# Altium schematic sheet BaseStation.SchDoc
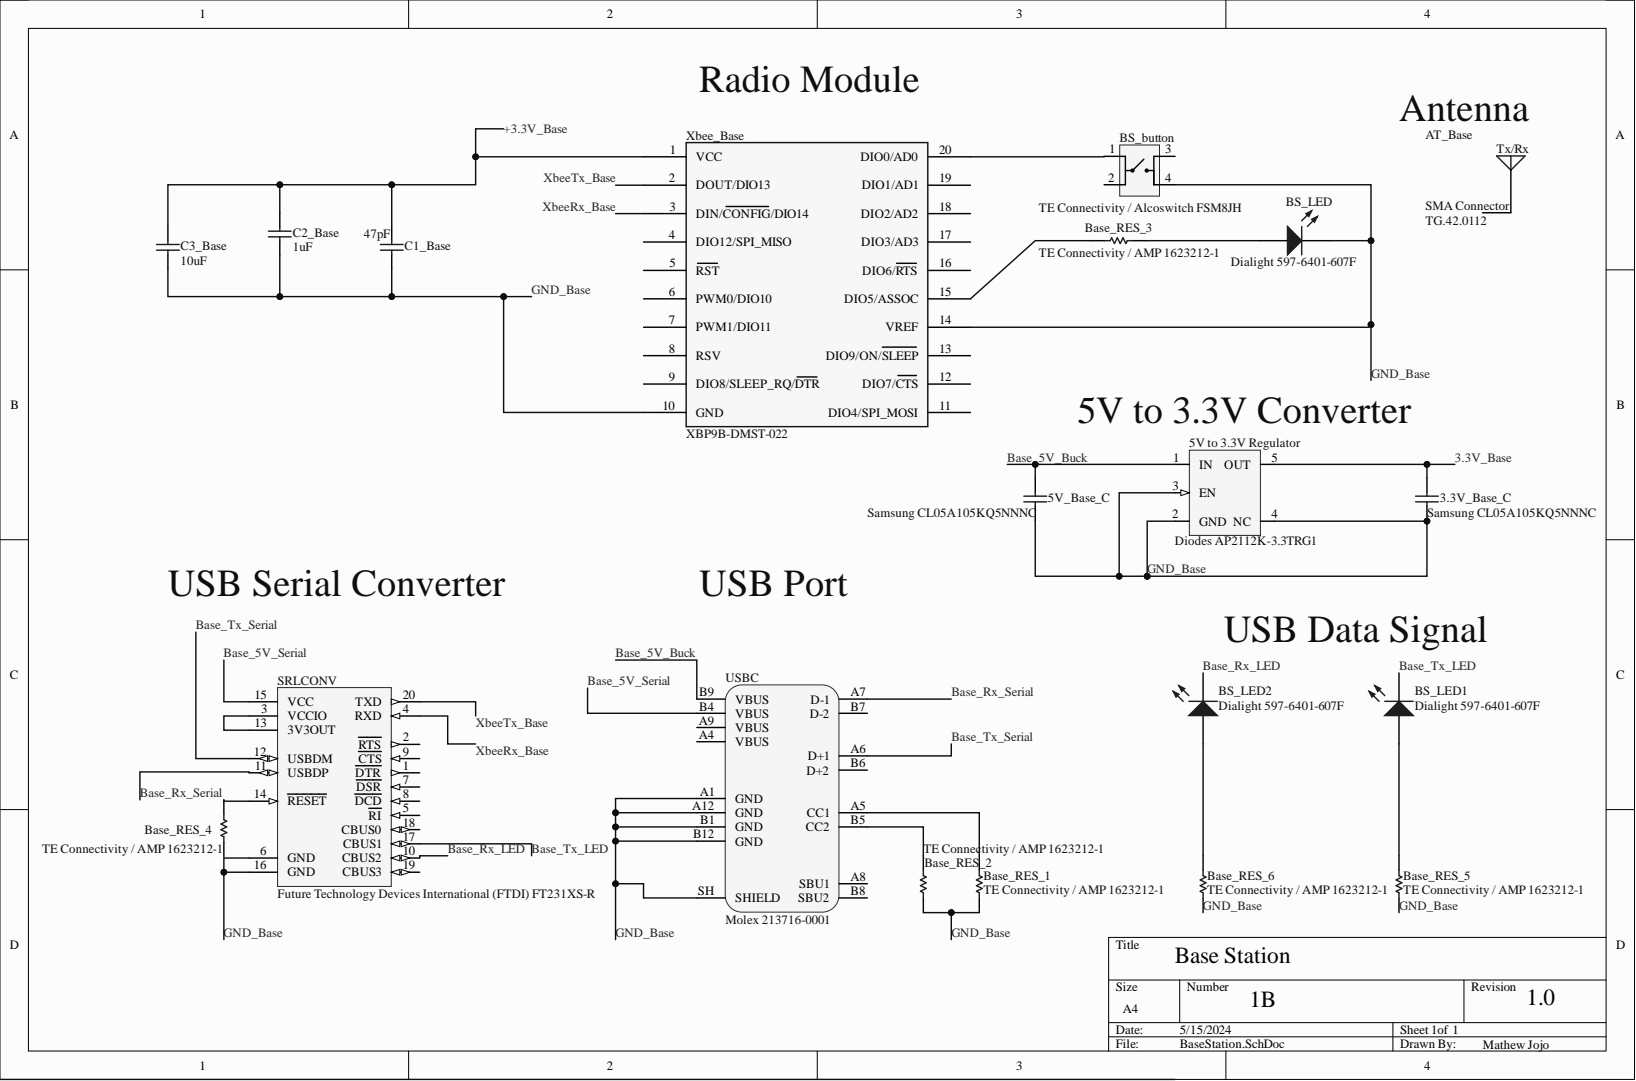
[diagram p0: BaseStation.SchDoc]

## Parts
3.3V_Base_C  Samsung CL05A105KQ5NNNC  [PCB-k5j45vo9cynmpwks6drn-1]
5V_to_3.3V_Regulator  Diodes AP2112K-3.3TRG1  Manufacturer Part Number: AP2112K-3.3TRG1  [SOT23-5_D]
5V_Base_C  Samsung CL05A105KQ5NNNC  Manufacturer Part Number: CL05A105KQ5NNNC  [PCB-k5j45vo9cynmpwks6drn-1]
AT_Base  TG.42.0112  [TG.42.0112-Footprint-1]
Base_RES_1  TE Connectivity / AMP 1623212-1  [PCB-kopdzqzjpr9jbzqzh48a-1]
Base_RES_2  TE Connectivity / AMP 1623212-1  [PCB-kopdzqzjpr9jbzqzh48a-1]
Base_RES_3  TE Connectivity / AMP 1623212-1  Manufacturer Part Number: 1623212-1  [PCB-kopdzqzjpr9jbzqzh48a-1]
Base_RES_4  TE Connectivity / AMP 1623212-1  Manufacturer Part Number: 1623212-1  [PCB-kopdzqzjpr9jbzqzh48a-1]
Base_RES_5  TE Connectivity / AMP 1623212-1  Manufacturer Part Number: 1623212-1  [PCB-kopdzqzjpr9jbzqzh48a-1]
Base_RES_6  TE Connectivity / AMP 1623212-1  [PCB-kopdzqzjpr9jbzqzh48a-1]
BS_button  TE Connectivity / Alcoswitch FSM8JH  [PCB-nybc64yyco8ubzlhb10m-1]
BS_LED  Dialight 597-6401-607F  Manufacturer Part Number: 597-6401-607F  [PCB-z3aqqik84ptkezzfxljl-1]
BS_LED1  Dialight 597-6401-607F  Manufacturer Part Number: 597-6401-607F  [PCB-z3aqqik84ptkezzfxljl-1]
BS_LED2  Dialight 597-6401-607F  [PCB-z3aqqik84ptkezzfxljl-1]
C1_Base  47pF  [CAPC2013X88X50LL20T25]
C2_Base  1uF  [CAPC3216X110X50ML20T25]
C3_Base  10uF  [CAPC3225X210X50ML20T25]
SRLCONV  Future Technology Devices International (FTDI) FT231XS-R  [SSOP20_L]
USBC  Molex 213716-0001  Manufacturer Part Number: 213716-0001  [Molex 213716-0001-Footprint-1]
Xbee_Base  XBP9B-DMST-022  [PCBComponent_1 - duplicate]

## Nets
3.3V_Base: 3.3V_Base_C.1 5V_to_3.3V_Regulator.5(OUT) C1_Base.2 C2_Base.2 C3_Base.2 Xbee_Base.1(VCC)  [also labelled +3.3V_Base]
Base_5V_Buck: 5V_to_3.3V_Regulator.1(IN) 5V_Base_C.1 USBC.B9(VBUS)
Base_5V_Serial: SRLCONV.15(VCC) USBC.B4(VBUS)
Base_Rx_LED: BS_LED2.2 SRLCONV.10(CBUS2)
Base_Rx_Serial: SRLCONV.11(USBDP) USBC.A7(D-1)
Base_Tx_LED: BS_LED1.2 SRLCONV.17(CBUS1)
Base_Tx_Serial: SRLCONV.12(USBDM) USBC.A6(D+1)
GND_Base: 3.3V_Base_C.2 5V_to_3.3V_Regulator.2(GND) 5V_to_3.3V_Regulator.3(EN) 5V_to_3.3V_Regulator.4(NC) 5V_Base_C.2 Base_RES_1.1 Base_RES_2.1 Base_RES_5.1 Base_RES_6.1 BS_button.4 BS_LED.2 C1_Base.1 C2_Base.1 C3_Base.1 SRLCONV.6(GND) SRLCONV.16(GND) USBC.A1(GND) USBC.A12(GND) USBC.B1(GND) USBC.B12(GND) USBC.SH(SHIELD) Xbee_Base.10(GND) Xbee_Base.14(VREF)
XbeeRx_Base: SRLCONV.4(RXD) Xbee_Base.3(DIN/CONFIG/DIO14)
XbeeTx_Base: SRLCONV.20(TXD) Xbee_Base.2(DOUT/DIO13)
NetBase_RES_1_2: Base_RES_1.2 USBC.A5(CC1)
NetBase_RES_2_2: Base_RES_2.2 USBC.B5(CC2)
NetBase_RES_3_1: Base_RES_3.1 Xbee_Base.15(DIO5/ASSOC)
NetBase_RES_3_2: Base_RES_3.2 BS_LED.1
NetBase_RES_4_2: Base_RES_4.2 SRLCONV.14(RESET)
NetBase_RES_5_2: Base_RES_5.2 BS_LED1.1
NetBase_RES_6_2: Base_RES_6.2 BS_LED2.1
NetBS_button_1: BS_button.1 Xbee_Base.20(DIO0/AD0)
NetSRLCONV_3: SRLCONV.3(VCCIO) SRLCONV.13(3V3OUT)

Not connected: Base_RES_4 1; BS_button 2 3; SRLCONV 1(DTR) 2(RTS) 5(RI) 7(DSR) 8(DCD) 9(CTS) 18(CBUS0) 19(CBUS3); USBC A4(VBUS) A8(SBU1) A9(VBUS) B6(D+2) B7(D-2) B8(SBU2); Xbee_Base 4(DIO12/SPI_MISO) 5(RST) 6(PWM0/DIO10) 7(PWM1/DIO11) 8(RSV) 9(DIO8/SLEEP_RQ/DTR) 11(DIO4/SPI_MOSI) 12(DIO7/CTS) 13(DIO9/ON/SLEEP) 16(DIO6/RTS) 17(DIO3/AD3) 18(DIO2/AD2) 19(DIO1/AD1)

## Library data of the parts
3.3V_Base_C 5V_Base_C = CMP-74a0bc84c90fab67-2: Ceramic capacitor SMD-0402 1000nF /6.3V X5R# K +-10%, Samsung, RoHS; Capacitance: 1.00 µF; Capacitance Tolerance: ±10%; Dielectric Characteristic: X5R; Lead-Free Status: Lead Free; Voltage Rating (DC): 6.30 V; Case/Package: 0402; Component Kind: Standard; Library Name: My Personal Space; Mounting Style: Surface Mount; Octopart URL: https://octopart.com/cl05a105kq5nnnc-samsung-19830985; OctopartID: 74a0bc84c90fab67; Operating Temperature: -55.0 °C (min); RoHS: Compliant
  3.3V_Base_C: Manufacturer Part Number: CL05A105KQ5NNNC
5V_to_3.3V_Regulator = CMP-15095236-4: AP2112 Series 0.6 A 3.3 V Fixed Output SMT LDO Linear Regulator - SOT23-5; Lead-Free Status: Lead Free; Component Kind: Standard; Library Name: My Personal Space; Lifecycle Status: Not Listed by Manufacturer; Octopart Url: http://octopart.com/ap2112k-3.3trg1-diodes+inc.-30954557; OctopartID: 0ec2c6107f99d105; RoHS: Compliant; Seller Landing  Url: https://octopart-clicks.com/click/track?country=US&ak=8157f166&sig=01867c6&sid=459&ppid=30954557&vpid=95776131&ct=offers
AT_Base = CMP-d62q0yr7xybwybambhsc-2: 4G LTE CONN MOUNT MONOPOLE ANT; Manufacturer: Taoglas Limited; Antenna Type: Whip, Right Angle; Frequency (Center/Band): 657.5MHz, 761MHz, 892MHz, 1.795GHz, 1.92GHz, 2.045GHz, 2.495GHz; Frequency Group: Wide Band; Frequency Range: 617MHz ~ 698MHz, 698MHz ~ 824MHz, 824MHz ~ 960MHz, 1.71GHz ~ 1.88GHz, 1.85GHz ~ 1.99GHz, 1.92GHz ~ 2.17GHz, 2.3GHz ~ 2.69GHz; Gain: 2.1dBi, 3.3dBi, 3.29dBi, 3.56dBi, 3.6dBi, 3.6dBi, 3.62dBi; Number of Bands: 7; Power - Max: 5 W; Component Kind: Standard; CustomComponentID: d62q0yr7xybwybambhsc; DigiKey Part Number: 931-TG.42.0112-ND; DigiKey URL: https://www.digikey.com.au/en/products/detail/taoglas-limited/TG-42-0112/17883242; Dimensions: 62.2x 17 x 7.8 mm; Height (Max): 2.449" (62.20mm); Library Name: My Personal Space; Manufacturer Product Number: TG.42.0112; Part Status: Active; Termination: SMA Male; Weight: 8 g
Base_RES_1-Base_RES_6 = CMP-2a5be30d9e5bf59c-1: Res Thick Film 0805 3K Ohm 1% 0.125W(1/8W) ±100ppm/C Pad SMD T/R; Case/Package (SI): 2012; ELV Compliant: Compliant; Power Rating: 125 mW; Resistance: 3.00 kΩ; Case/Package: 0805; Component Kind: Standard; Library Name: My Personal Space; Lifecycle Status: Active; Mounting Style: Surface Mount; Octopart URL: https://octopart.com/1623212-1-te+connectivity+%2F+amp-6066534; OctopartID: 2a5be30d9e5bf59c; Packaging: Tape & Reel (TR); RoHS: Compliant
  Base_RES_1 Base_RES_2 Base_RES_6: Manufacturer Part Number: 1623212-1
BS_button = CMP-666aad1cd84eb9ad-3: Switch Tactile OFF (ON) SPST Extended Round Button PC Pins 0.05A 12VDC 1.57N Thru-Hole Loose Piece; Contact Resistance: 100 mΩ; Contacts Type: SPST-NO; ELV Compliant: Compliant; Color: Black; Component Kind: Standard; Contact Material: Copper Alloy; Contact Plating: Silver; Insulation Resistance: 100 MΩ; Library Name: My Personal Space; Lifecycle Status: Obsolete; Manufacturer Part Number: FSM8JH; Mounting Style: Through Hole; Octopart URL: http://octopart.com/fsm8jh-te+connectivity+%2F+alcoswitch-41720642; OctopartID: 666aad1cd84eb9ad; REACH SVHC Compliance: No SVHC; RoHS: Compliant
BS_LED BS_LED1 BS_LED2 = CMP-ea0c237a335835cb-5: LED Uni-Color Yellow 588nm 2-Pin Chip 1206(3216Metric) T/R; Forward Voltage: 2.00 V; Lead-Free Status: Lead Free; Luminous Intensity: 450 mcd; Viewing Angle: 70 °; Case/Package: 1206; Component Kind: Standard; Library Name: My Personal Space; Mounting Style: Surface Mount; Number of Pins: 2; Octopart URL: https://octopart.com/597-6401-607f-dialight-8191026; OctopartID: ea0c237a335835cb; Packaging: Reel; RoHS: Compliant
  BS_LED2: Manufacturer Part Number: 597-6401-607F
C1_Base = CMP-145041-2: Multilayer Ceramic Capacitors MLCC - SMD/SMT 100volts 47pF C0G 5%; Manufacturer Part Number: C0805C470J1GACTU; Capacitance: 4.7e-11 F; Dielectric Characteristic: C0G/NP0; Lead-Free Status: Lead Free; Voltage Rating (DC): 100.0 V; Capacitance Tolerance: ±5%; Case/Package: 0805; Component Kind: Standard; Dielectric Material: Ceramic; Library Name: My Personal Space; Mounting Style: Surface Mount; Number of Pins: 2; Octopart Url: https://octopart.com/c0805c470j1gactu-kemet-9138638; OctopartID: c90c008d9da416cb; REACH SVHC Compliance: No SVHC; RoHS: Compliant
C2_Base = CMP-2511450-3: Capacitor 1206 1uF 50V; Manufacturer Part Number: C1206C105K5RACTU; Capacitance: 1e-06 F; Capacitance Tolerance: ±10%; Dielectric Characteristic: X7R; Dielectric Material: Ceramic; Lead-Free Status: R; Voltage Rating (DC): 50.0 V; Case/Package: 1206; Component Kind: Standard; Library Name: My Personal Space; Mounting Style: Surface Mount; Octopart Url: https://octopart.com/c1206c105k5rac7800-kemet-2032916; OctopartID: 0a65c3702a17d5a2; RoHS: Compliant
C3_Base = CMP-7585346-2: Cap Ceramic 10uF 35V X5R 20% SMD 1210 85C Plastic T/R; Manufacturer Part Number: C1210C106M6PACTU; Capacitance: 1e-05 F; Lead-Free Status: Lead Free; Voltage Rating (DC): 35.0 V; Capacitance Tolerance: ±20%; Case/Package: 1210; Component Kind: Standard; Dielectric Characteristic: X5R; Dielectric Material: Ceramic; Library Name: My Personal Space; Mounting Style: Surface Mount; Octopart Url: https://octopart.com/c1210c106m6pac7800-kemet-21768613; OctopartID: 408588c34c469f42; RoHS: Compliant
SRLCONV = CMP-1315745-4: USB Full Speed to Full Handshake UART with USB Charger Detection; Component Kind: Standard; Library Name: My Personal Space; Manufacturer Part Number: FT231XS-R; Mounting Style: Surface Mount; Octopart Url: http://octopart.com/ft231xs-r-ftdi-22061507; OctopartID: 526a9a30d6cf8dad; Packaging: Tape; RoHS: Compliant; Seller Landing  Url: https://octopart-clicks.com/click/track?country=US&ak=8157f166&sig=0ac6205&sid=459&ppid=22061507&vpid=46595747&ct=offers
USBC = CMP-d1611ea6e82e0ea6-9: Connector USB Type C Receptacle 16 Position 0.5mm Surface Mount Right Angle Emboss T/R; Value: USB-C; Component Kind: Standard; Description_CMP: Molex 213716-0001; Library Name: My Personal Space; Lifecycle Status: Not Listed by Manufacturer; Octopart Url: https://octopart.com/213716-0001-molex-114105385; OctopartID: d1611ea6e82e0ea6
Xbee_Base = CMP-3c23f466d5ffe3e4-23: General ISM < 1GHz Transceiver Module 900MHz Antenna Not Included, RP-SMA Through Hole; Utilized IC / Part: ADF7023; Current - Receiving: 44mA; Current - Transmitting: 229mA; Data Rate: 10 kbps; Lead-Free Status: Lead Free; Modulation: FHSS; Power - Output: 24dBm; ReplaceComponentId: PMSVODID; Sensitivity: -109dBm; Supply Voltage (DC): 2.4V ~ 3.6V; Component Kind: Standard; DigiKey URL: https://www.digikey.com.au/en/products/detail/digi/XBP9B-DMST-022/3728682?WT.z_cid=ref_neda_dkc_buynow_digiintl&utm_source=ecia&utm_medium=aggregator&utm_campaign=digiintl; Frequency: 900MHz; Library Name: My Personal Space; Manufacturer Part Number: XBP9B-DMST-022; Mounting Type: Through Hole; OctopartID: 3c23f466d5ffe3e4; Operating Temperature: -40°C ~ 85°C; Part Status: Active; PartId: 1; RF Family/Standard: General ISM < 1GHz; RoHS: Compliant
